# Altium schematic sheet location.SchDoc(location)
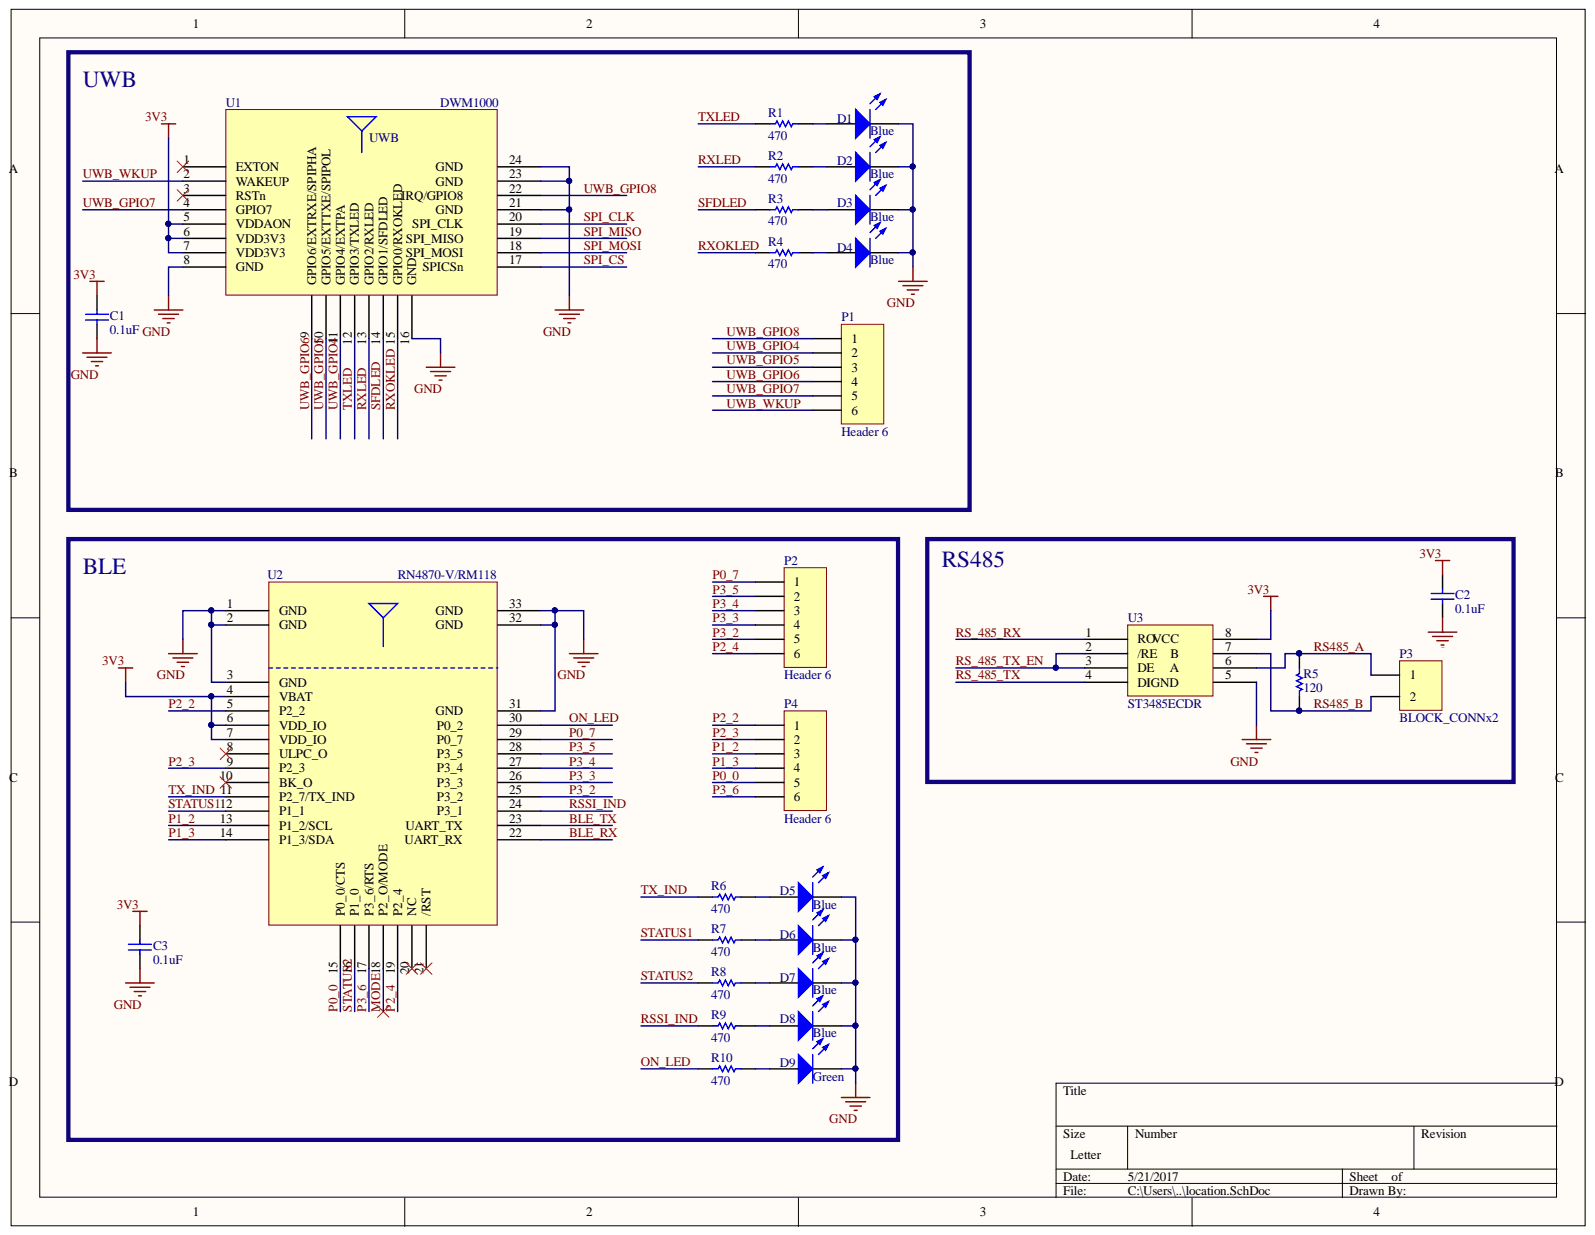
[diagram p0: location.SchDoc]

## Parts
C1  0.1uF  [C0603_N]
C2  0.1uF  [C0603_N]
C3  0.1uF  [C0603_N]
D1  Blue  [R0603_N]
D2  Blue  [R0603_N]
D3  Blue  [R0603_N]
D4  Blue  [R0603_N]
D5  Blue  [R0603_N]
D6  Blue  [R0603_N]
D7  Blue  [R0603_N]
D8  Blue  [R0603_N]
D9  Green  [R0603_N]
P1  Header 6  [HDR1X6]
P2  Header 6  [HDR1X6]
P3  BLOCK_CONNx2  [BLOCK_CONNX2]
P4  Header 6  [HDR1X6]
R1  470  [R0603_N]
R2  470  [R0603_N]
R3  470  [R0603_N]
R4  470  [R0603_N]
R5  120  [R0603_N]
R6  470  [R0603_N]
R7  470  [R0603_N]
R8  470  [R0603_N]
R9  470  [R0603_N]
R10  470  [R0603_N]
U1  DWM1000  [DWM1000]
U2  RN4870-V/RM118  [RN4870]
U3  ST3485ECDR  [SOIC8WA_N]

## Nets
3V3: C1.2 C2.1 C3.2 U1.5(VDDAON) U1.6(VDD3V3) U1.7(VDD3V3) U2.4(VBAT) U2.6(VDD_IO) U2.7(VDD_IO) U3.8
GND: C1.1 C2.2 C3.1 D1.2 D2.2 D3.2 D4.2 D5.2 D6.2 D7.2 D8.2 D9.2 U1.8(GND) U1.16(GND) U1.21 U1.23 U1.24 U2.1(GND) U2.2(GND) U2.3(GND) U2.31 U2.32 U2.33 U3.5
BLE_RX: U2.22
BLE_TX: U2.23
MODE: U2.18(P2_O/MODE)
ON_LED: R10.2 U2.30
P0_0: P4.5 U2.15(P0_0/CTS)
P0_7: P2.1 U2.29
P1_2: P4.3 U2.13(P1_2/SCL)
P1_3: P4.4 U2.14(P1_3/SDA)
P2_2: P4.1 U2.5(P2_2)
P2_3: P4.2 U2.9(P2_3)
P2_4: P2.6 U2.19(P2_4)
P3_2: P2.5 U2.25
P3_3: P2.4 U2.26
P3_4: P2.3 U2.27
P3_5: P2.2 U2.28
P3_6: P4.6 U2.17(P3_6/RTS)
RS485_A: P3.1 R5.1 U3.6
RS485_B: P3.2 R5.2 U3.7
RS_485_RX: U3.1(RO)
RS_485_TX: U3.4(DI_GND)
RS_485_TX_EN: U3.2(/RE) U3.3(DE)
RSSI_IND: R9.2 U2.24
RXLED: R2.2 U1.13(GPIO2/RXLED)
RXOKLED: R4.2 U1.15(GPIO0/RXOKLED)
SFDLED: R3.2 U1.14(GPIO1/SFDLED)
SPI_CLK: U1.20
SPI_CS: U1.17
SPI_MISO: U1.19
SPI_MOSI: U1.18
STATUS1: R7.2 U2.12(P1_1)
STATUS2: R8.2 U2.16(P1_0)
TX_IND: R6.2 U2.11(P2_7/TX_IND)
TXLED: R1.2 U1.12(GPIO3/TXLED)
UWB_GPIO4: P1.2 U1.11(GPIO4/EXTPA)
UWB_GPIO5: P1.3 U1.10(GPIO5/EXTTXE/SPIPOL)
UWB_GPIO6: P1.4 U1.9(GPIO6/EXTRXE/SPIPHA)
UWB_GPIO7: P1.5 U1.4(GPIO7)
UWB_GPIO8: P1.1 U1.22
UWB_WKUP: P1.6 U1.2(WAKEUP)
NetD1_1: D1.1 R1.1
NetD2_1: D2.1 R2.1
NetD3_1: D3.1 R3.1
NetD4_1: D4.1 R4.1
NetD5_1: D5.1 R6.1
NetD6_1: D6.1 R7.1
NetD7_1: D7.1 R8.1
NetD8_1: D8.1 R9.1
NetD9_1: D9.1 R10.1

Not connected: U1 1(EXTON) 3(RSTn); U2 8(ULPC_O) 10(BK_O) 20(NC) 21(/RST)

## Library data of the parts
C1-C3 = C_SMD: Component Kind: Standard; Library Name: General.IntLib; Value: 0.1uF
D1-D9 = LED_SMD: Component Kind: Standard; Library Name: discrete.SchLib
  D1-D8: Color: Blue
  D9: Color: Green
P1 P2 P4 = Header 6: Header, 6-Pin; Component Kind: Standard; LatestRevisionDate: 17-Jul-2002; LatestRevisionNote: Re-released for DXP Platform.; Library Name: Miscellaneous Connectors.IntLib; Signal Integrity: Connector; Publisher: Altium Limited
P3 = BLOCK_CONNx2: TERM BLOCK 5.08MM VERT 2POS PCB; Manufacturer: On Shore Technology Inc; Manufacturer Part Number: OSTTC022162; Clamp Material - Plating: Steel, Stainless; Current: 15A; Mating Orientation: Horizontal with Board; Number of Levels: 1; Pitch: 0.200" (5.08mm); Positions Per Level: 2; Screw Material - Plating: Steel - Zinc Plated; Screw Thread: M2.6; Torque - Screw: 0.3 Nm (2.6 Lb-In); Voltage: 300V; Wire Gauge: 14-22 AWG; Wire Termination: Screw - Leaf Spring, Wire Guard; Category: Connectors, Interconnects; Color: Blue; Component Kind: Standard; ComponentLink1Description: http://www.on-shore.com/sites/default/files/manuals/osttcxx2162.pdf; ComponentLink1URL: http://www.on-shore.com/sites/default/files/manuals/osttcxx2162.pdf; Contact Material - Plating: Tin Plated; Features: Interlocking (Side); Housing Material: Thermoplastic; Library Name: General.IntLib; Mounting Type: Through Hole; Operating Temperature: -30°C ~ 105°C; Packaging: Bulk; Pricing 1: 1=0.41, 10=0.385, 50=0.2806, 100=0.2695, 250=0.242, 500=0.231, 1000=0.1925, 2500=0.176, 5000=0.165 (USD); RoHS: RoHS Compliant; Stock 1: 37906; Supplier 1: Digi-Key; Supplier Part Number 1: ED2609-ND
R1-R4 R6-R10 = R_SMD: Component Kind: Standard; Library Name: discrete.SchLib; Value: 470
R5 = R_SMD: Manufacturer: Yageo; Manufacturer Part Number: RC0603JR-07120RL; Power (Watts): 0.1W, 1/10W; Temperature Coefficient: ±100ppm/°C; Tolerance: ±5%; Category: Resistors; Component Kind: Standard; ComponentLink1Description: http://www.yageo.com/documents/recent/PYu-RC_Group_51_RoHS_L_7.pdf; ComponentLink1URL: http://www.yageo.com/documents/recent/PYu-RC_Group_51_RoHS_L_7.pdf; Composition: Thick Film; Failure Rate: -; Features: Moisture Resistant; Height - Seated (Max): 0.022" (0.55mm); Library Name: General.IntLib; Operating Temperature: -55°C ~ 155°C; Package / Case: 0603 (1608 Metric); Packaging: Cut Tape (CT); Part Status: Active; Pricing 1: 1=0.1, 10=0.011, 100=0.0044, 1000=0.00198, 2500=0.00172 (USD); Resistance (Ohms): 120; RoHS: RoHS Compliant; Size / Dimension: 0.063" L x 0.031" W (1.60mm x 0.80mm); Stock 1: 479377; Supplier 1: Digi-Key; Supplier Device Package: 0603; Supplier Part Number 1: 311-120GRCT-ND; Value: 120
U1 = DWM1000: RF TXRX MODULE 802.15.4 CHIP ANT; Manufacturer: Decawave Limited; Antenna Type: Integrated, Chip; Current - Receiving: 64mA; Current - Transmitting: 31mA; Data Rate: 6.8Mbps; Frequency: 3.5GHz ~ 6.5GHz; Modulation: BPM, BPSK; Protocol: IR-UWB; Serial Interfaces: SPI; Voltage - Supply: 2.8 V ~ 3.6 V; Category: RF/IF and RFID; Component Kind: Standard; ComponentLink1Description: http://www.decawave.com/sites/default/files/resources/DWM1000-Datasheet-V1.6.pdf; ComponentLink1URL: http://www.decawave.com/sites/default/files/resources/DWM1000-Datasheet-V1.6.pdf; Library Name: General.SchLib; Manufacturer Part Number: DWM1000; Memory Size: -; Mounting Type: Surface Mount; Operating Temperature: -40°C ~ 85°C; Package / Case: Module; Packaging: Cut Tape (CT); Part Status: Active; Power - Output: -; Pricing 1: 1=25.59, 10=23.625, 25=21.6564, 100=20.0156, 250=19.03124 (USD); RF Family/Standard: 802.15.4; RoHS: RoHS Compliant; Sensitivity: -; Stock 1: 34389; Supplier 1: Digi-Key; Supplier Part Number 1: 1479-1002-1-ND
U2 = RN4870-V/RM118: BLUETOOTH 4.2 BLE MODULE, SHIELD; Manufacturer: Microchip Technology; Antenna Type: Integrated, Chip; Current - Receiving: 13mA; Frequency: 2.4GHz; Modulation: GFSK; Power - Output: 0dBm; Protocol: Bluetooth v4.2; Sensitivity: -90dBm; Serial Interfaces: UART; Voltage - Supply: 1.9 V ~ 3.6 V; Category: RF/IF and RFID; Component Kind: Standard; ComponentLink1Description: http://www.microchip.com/mymicrochip/filehandler.aspx?ddocname=en586027; ComponentLink1URL: http://www.microchip.com/mymicrochip/filehandler.aspx?ddocname=en586027; Current - Transmitting: 13mA; Data Rate: -; Library Name: General.IntLib; Manufacturer Part Number: RN4870-V/RM118; Memory Size: -; Mounting Type: Surface Mount; Operating Temperature: -20°C ~ 70°C; Package / Case: 33-SMD Module; Packaging: Tray; Part Status: Active; Pricing 1: 1=7.24, 25=6.5612, 100=6.353 (USD); RF Family/Standard: Bluetooth; RoHS: RoHS Compliant; Stock 1: 558; Supplier 1: Digi-Key; Supplier Part Number 1: RN4870-V/RM118-ND
U3 = ST3485ECDR: TXRX RS485/422 3.3V ESD 8-SOIC; Manufacturer: STMicroelectronics; Data Rate: 15Mbps; Duplex: Half; Number of Drivers/Receivers: 1/1; Protocol: RS422, RS485; Receiver Hysteresis: 70mV; Voltage - Supply: 3 V ~ 3.6 V; Category: Integrated Circuits (ICs); Component Kind: Standard; ComponentLink1Description: http://www.st.com/web/en/resource/technical/document/datasheet/CD00003137.pdf; ComponentLink1URL: http://www.st.com/web/en/resource/technical/document/datasheet/CD00003137.pdf; Library Name: General.IntLib; Manufacturer Part Number: ST3485ECDR; Mounting Type: Surface Mount; Operating Temperature: 0°C ~ 70°C; Package / Case: 8-SOIC (0.154", 3.90mm Width); Packaging: Cut Tape (CT); Pricing 1: 1=2.44, 10=2.206, 25=1.9764, 100=1.7772, 250=1.578, 500=1.37884, 1000=1.11899 (USD); RoHS: RoHS Compliant; Stock 1: 2539; Supplier 1: Digi-Key; Supplier Device Package: 8-SO; Supplier Part Number 1: 497-6540-1-ND; Type: Transceiver
